# Altium schematic sheet Peripherals.SchDoc(Peripherals)
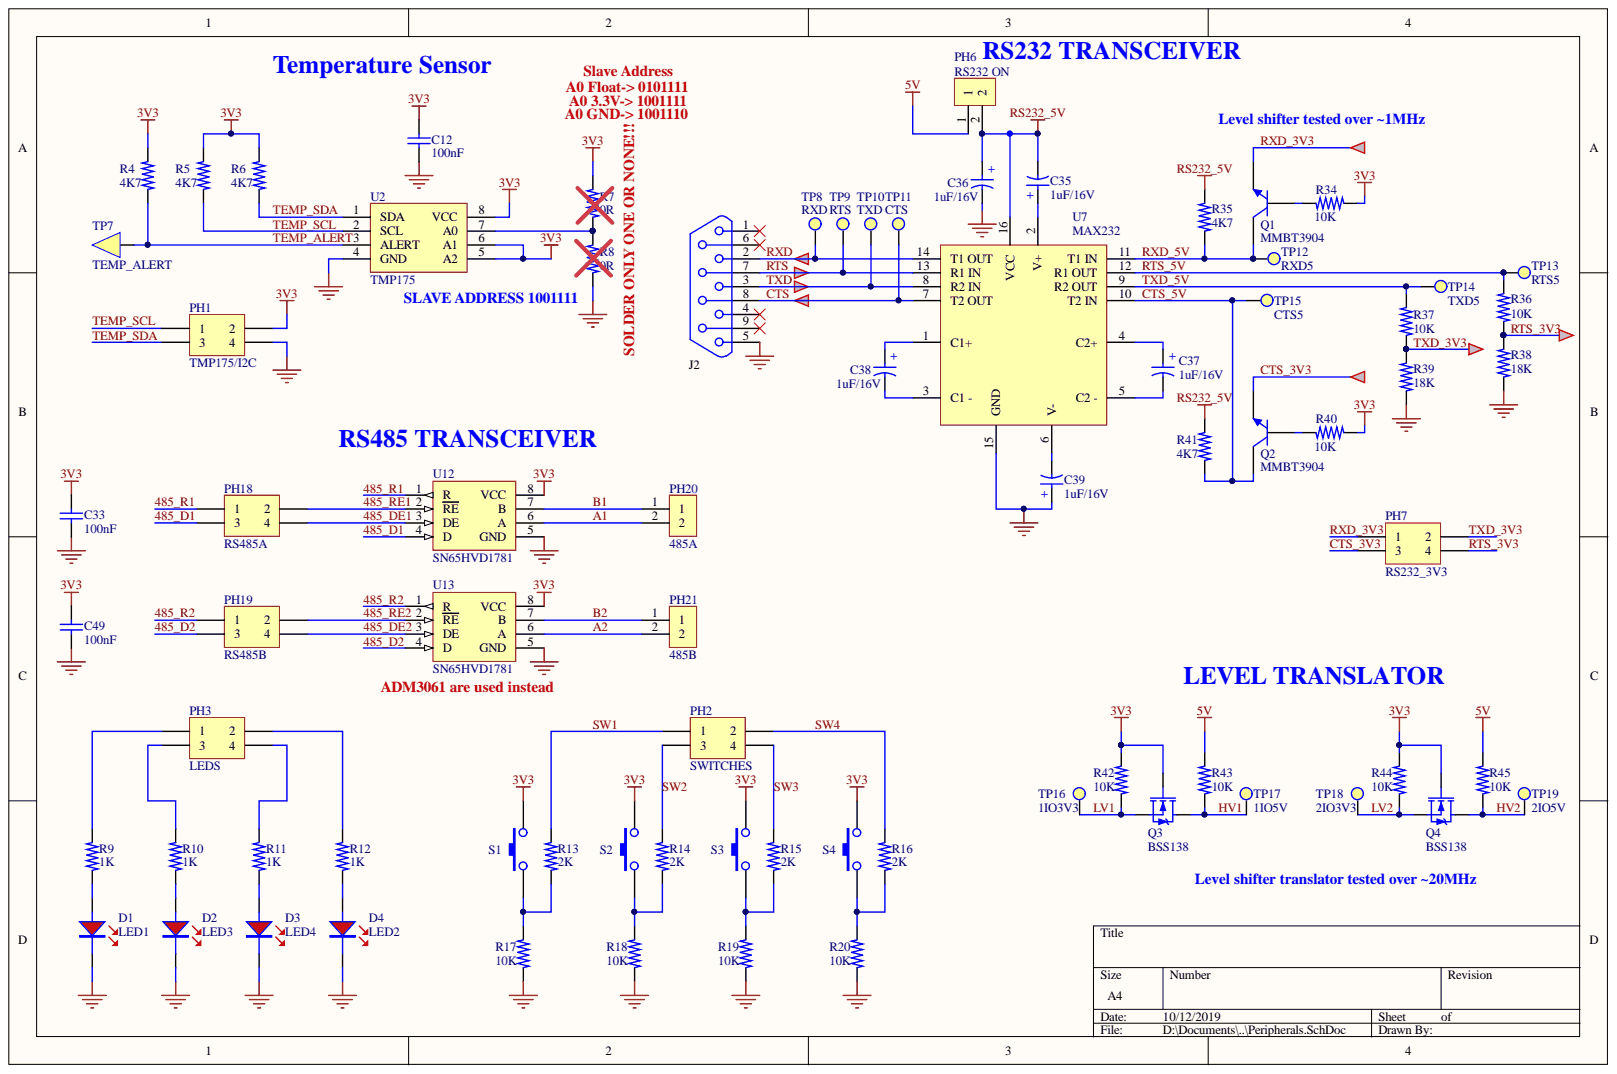
[diagram p0: Peripherals.SchDoc]

## Parts
C12  100nF  [0805Big_C]
C33  100nF  [0805Big_C]
C35  1uF/16V  [Tant3216_18]
C36  1uF/16V  [Tant3216_18]
C37  1uF/16V  [Tant3216_18]
C38  1uF/16V  [Tant3216_18]
C39  1uF/16V  [Tant3216_18]
C49  100nF  [0805Big_C]
D1  LED1  [0805_Led]
D2  LED3  [0805_Led]
D3  LED4  [0805_Led]
D4  LED2  [0805_Led]
J2  RS232 DB9F  [DB9FL]  (value not drawn)
PH1  TMP175/I2C  [HDR2X2]
PH2  SWITCHES  [HDR2X2]
PH3  LEDS  [HDR2X2]
PH6  RS232 ON  [SIP2]
PH7  RS232_3V3  [HDR2X2]
PH18  RS485A  [HDR2X2]
PH19  RS485B  [HDR2X2]
PH20  485A  [SIP2]
PH21  485B  [SIP2]
Q1  MMBT3904  [SOT-23]
Q2  MMBT3904  [SOT-23]
Q3  BSS138  [SOT-23]
Q4  BSS138  [SOT-23]
R4  4K7  [0805Big_R]
R5  4K7  [0805Big_R]
R6  4K7  [0805Big_R]
R7  0R  [0805Big_R]
R8  0R  [0805Big_R]
R9  1K  [0805Big_R]
R10  1K  [0805Big_R]
R11  1K  [0805Big_R]
R12  1K  [0805Big_R]
R13  2K  [0805Big_R]
R14  2K  [0805Big_R]
R15  2K  [0805Big_R]
R16  2K  [0805Big_R]
R17  10K  [0805Big_R]
R18  10K  [0805Big_R]
R19  10K  [0805Big_R]
R20  10K  [0805Big_R]
R34  10K  [0805Big_R]
R35  4K7  [0805Big_R]
R36  10K  [0805Big_R]
R37  10K  [0805Big_R]
R38  18K  [0805Big_R]
R39  18K  [0805Big_R]
R40  10K  [0805Big_R]
R41  4K7  [0805Big_R]
R42  10K  [0805Big_R]
R43  10K  [0805Big_R]
R44  10K  [0805Big_R]
R45  10K  [0805Big_R]
S1  Button  [SW_SMD]  (value not drawn)
S2  Button  [SW_SMD]  (value not drawn)
S3  Button  [SW_SMD]  (value not drawn)
S4  Button  [SW_SMD]  (value not drawn)
TP7  TEMP_ALERT  [PIN0MM9]
TP8  RXD  [PIN0MM9]
TP9  RTS  [PIN0MM9]
TP10  TXD  [PIN0MM9]
TP11  CTS  [PIN0MM9]
TP12  RXD5  [PIN0MM9]
TP13  RTS5  [PIN0MM9]
TP14  TXD5  [PIN0MM9]
TP15  CTS5  [PIN0MM9]
TP16  1IO3V3  [PIN0MM9]
TP17  1IO5V  [PIN0MM9]
TP18  2IO3V3  [PIN0MM9]
TP19  2IO5V  [PIN0MM9]
U2  TMP175  [SOIC-8]
U7  MAX232  [SOIC-16]
U12  SN65HVD1781  [SOIC-8]
U13  SN65HVD1781  [SOIC-8]

## Nets
3V3: C12.1 C33.1 C49.1 PH1.2 Q3.3 Q4.3 R4.2 R5.2 R6.2 R7.2 R34.1 R40.1 R42.1 R44.1 S1.2 S2.2 S3.2 S4.2 U2.5(A2) U2.6(A1) U2.8(VCC) U12.8(VCC) U13.8(VCC)
5V: PH6.1 R43.1 R45.1
GND: C12.2 C33.2 C36.2 C39.1 C49.2 D1.K D2.K D3.K D4.K J2.5 PH1.4 R8.1 R17.1 R18.1 R19.1 R20.1 R38.2 R39.2 U2.4(GND) U7.15(GND) U12.5(GND) U13.5(GND)
RS232_5V: C35.2 C36.1 PH6.2 R35.2 R41.2 U7.16(VCC)
485_D1: PH18.3 U12.4(D)
485_D2: PH19.3 U13.4(D)
485_DE1: PH18.4 U12.3(DE)
485_DE2: PH19.4 U13.3(DE)
485_R1: PH18.1 U12.1(R)
485_R2: PH19.1 U13.1(R)
485_RE1: PH18.2 U12.2(RE)
485_RE2: PH19.2 U13.2(RE)
A1: PH20.2 U12.6(A)
A2: PH21.2 U13.6(A)
B1: PH20.1 U12.7(B)
B2: PH21.1 U13.7(B)
CTS: J2.8 TP11.1 U7.7(T2_OUT)
CTS_3V3: PH7.3 Q2.2
CTS_5V: Q2.3 R41.1 TP15.1 U7.10(T2_IN)
HV1: Q3.1 R43.2 TP17.1
HV2: Q4.1 R45.2 TP19.1
LV1: Q3.2 R42.2 TP16.1
LV2: Q4.2 R44.2 TP18.1
RTS: J2.7 TP9.1 U7.13(R1_IN)
RTS_3V3: PH7.4 R36.2 R38.1
RTS_5V: R36.1 TP13.1 U7.12(R1_OUT)
RXD: J2.2 TP8.1 U7.14(T1_OUT)
RXD_3V3: PH7.1 Q1.2
RXD_5V: Q1.3 R35.1 TP12.1 U7.11(T1_IN)
SW1: PH2.1 R13.1
SW2: PH2.3 R14.1
SW3: PH2.4 R15.1
SW4: PH2.2 R16.1
TEMP_ALERT: R4.1 TP7.1 U2.3(ALERT)
TEMP_SCL: PH1.1 R5.1 U2.2(SCL)
TEMP_SDA: PH1.3 R6.1 U2.1(SDA)
TXD: J2.3 TP10.1 U7.8(R2_IN)
TXD_3V3: PH7.2 R37.2 R39.1
TXD_5V: R37.1 TP14.1 U7.9(R2_OUT)
NetC35_1: C35.1 U7.2(V+)
NetC37_1: C37.1 U7.4(C2+)
NetC37_2: C37.2 U7.5(C2_-)
NetC38_1: C38.1 U7.1(C1+)
NetC38_2: C38.2 U7.3(C1_-)
NetC39_2: C39.2 U7.6(V-)
NetD1_A: D1.A R9.2
NetD2_A: D2.A R10.2
NetD3_A: D3.A R11.2
NetD4_A: D4.A R12.2
NetPH3_1: PH3.1 R9.1
NetPH3_2: PH3.2 R12.1
NetPH3_3: PH3.3 R10.1
NetPH3_4: PH3.4 R11.1
NetQ1_1: Q1.1 R34.2
NetQ2_1: Q2.1 R40.2
NetR7_1: R7.1 R8.2 U2.7(A0)
NetR13_2: R13.2 R17.2 S1.1
NetR14_2: R14.2 R18.2 S2.1
NetR15_2: R15.2 R19.2 S3.1
NetR16_2: R16.2 R20.2 S4.1

Not connected: J2 1 4 6 9

## Library data of the parts
C12 C33 C49 = CapCerSMD: Capacitor Ceramic SMD; Simulation: Model Name; Library Name: MyLibrary.IntLib; Component Kind: Standard
C35-C39 = CapTant: Capacitor Tantalum; Simulation: Model Name; Library Name: MyLibrary.IntLib; Component Kind: Standard
D1-D4 = LED: Library Name: MyComponents.SchLib; Description: LED; Component Kind: Standard
J2 = DB9: RS-232 Board Mounted connector (Female); Library Name: Connectors.SchLib; Component Kind: Standard
PH1-PH3 PH7 PH18 PH19 = Header 2X2: Header, 2-Pin, Dual row; Library Name: MyLibrary.IntLib; Component Kind: Standard
PH6 PH20 PH21 = Header2: One row 2 pins Header; Component Kind: Standard
  PH6: Library Name: Connectors.SchLib
  PH20 PH21: Library Name: MyLibrary.IntLib
Q1 Q2 = MMBT3904: NPN Switching Transistor; Simulation: QMMBT3904; Signal Integrity: MMBT3904; Published: 17-Sep-2003; PackageVersion: May-1999; PackageReference: SOT23; PackageDescription: 3-Pin SOT-23 Package 0.95 mm Pitch, 2.3 mm Lead Span; Library Name: MyLibrary.IntLib; LatestRevisionNote: IPC-7351 Footprint Added.; LatestRevisionDate: 12-Dec-2006; DatasheetVersion: 13-May-2002; ComponentLink2URL: http://www.semiconductors.philips.com/acrobat/datasheets/MMBT3904_4.pdf; ComponentLink2Description: Datasheet; ComponentLink1URL: http://www.semiconductors.philips.com/; ComponentLink1Description: Manufacturer Link; Component Kind: Standard; Publisher: Altium Limited
Q3 Q4 = BSS138: N-Channel Enhancement Mode Vertical DMOS FET; Simulation: BSS138; Published: 17-Dec-2003; PackageVersion: 1996; PackageReference: SOT23; PackageDescription: SOT-23; 3 Leads; Body 3.1 x 2.5 mm, inc. leads (LxW max), Alternate Lead Configuration; Library Name: MyLibrary.IntLib; DatasheetVersion: Mar-96; ComponentLink2URL: www.zetex.com/3.0/pdf/BSS138.pdf; ComponentLink2Description: Datasheet; ComponentLink1URL: http://www.zetex.com/; ComponentLink1Description: Manufacturer Link; Component Kind: Standard; Publisher: Altium Limited
R4-R20 R34-R45 = ResSMD: Resistor SMD; Simulation: Model Name; Library Name: MyLibrary.IntLib; Component Kind: Standard
  R42-R45: Value: 10K
S1-S4 = Button_Start: Push Button; Component Kind: Standard; Library Name: NMEAlib.IntLib
TP7 = PIN_OUT: Output Pin; Library Name: MyLibrary.IntLib; Component Kind: Standard
TP8-TP19 = PIN_TEST: PIC Enhanced Flash USB Microcontroller; Library Name: MyComponents.SchLib; Component Kind: Standard
U2 = TMP175: Sensor, Temperature; Library Name: Digital.SchLib; Component Kind: Standard
U7 = MAX232: +-15KV ESD-PROTECTED, +5V RS-232 TRANSCEIVERS; Library Name: MyComponents.SchLib; Component Kind: Standard
U12 U13 = SN65HVD1781: Fault-Protected RS-485 Transceivers with 3.3-V to 5-V Operation; Library Name: MyLibrary.IntLib; Component Kind: Standard
